# Altium schematic sheet Sheet1.SchDoc
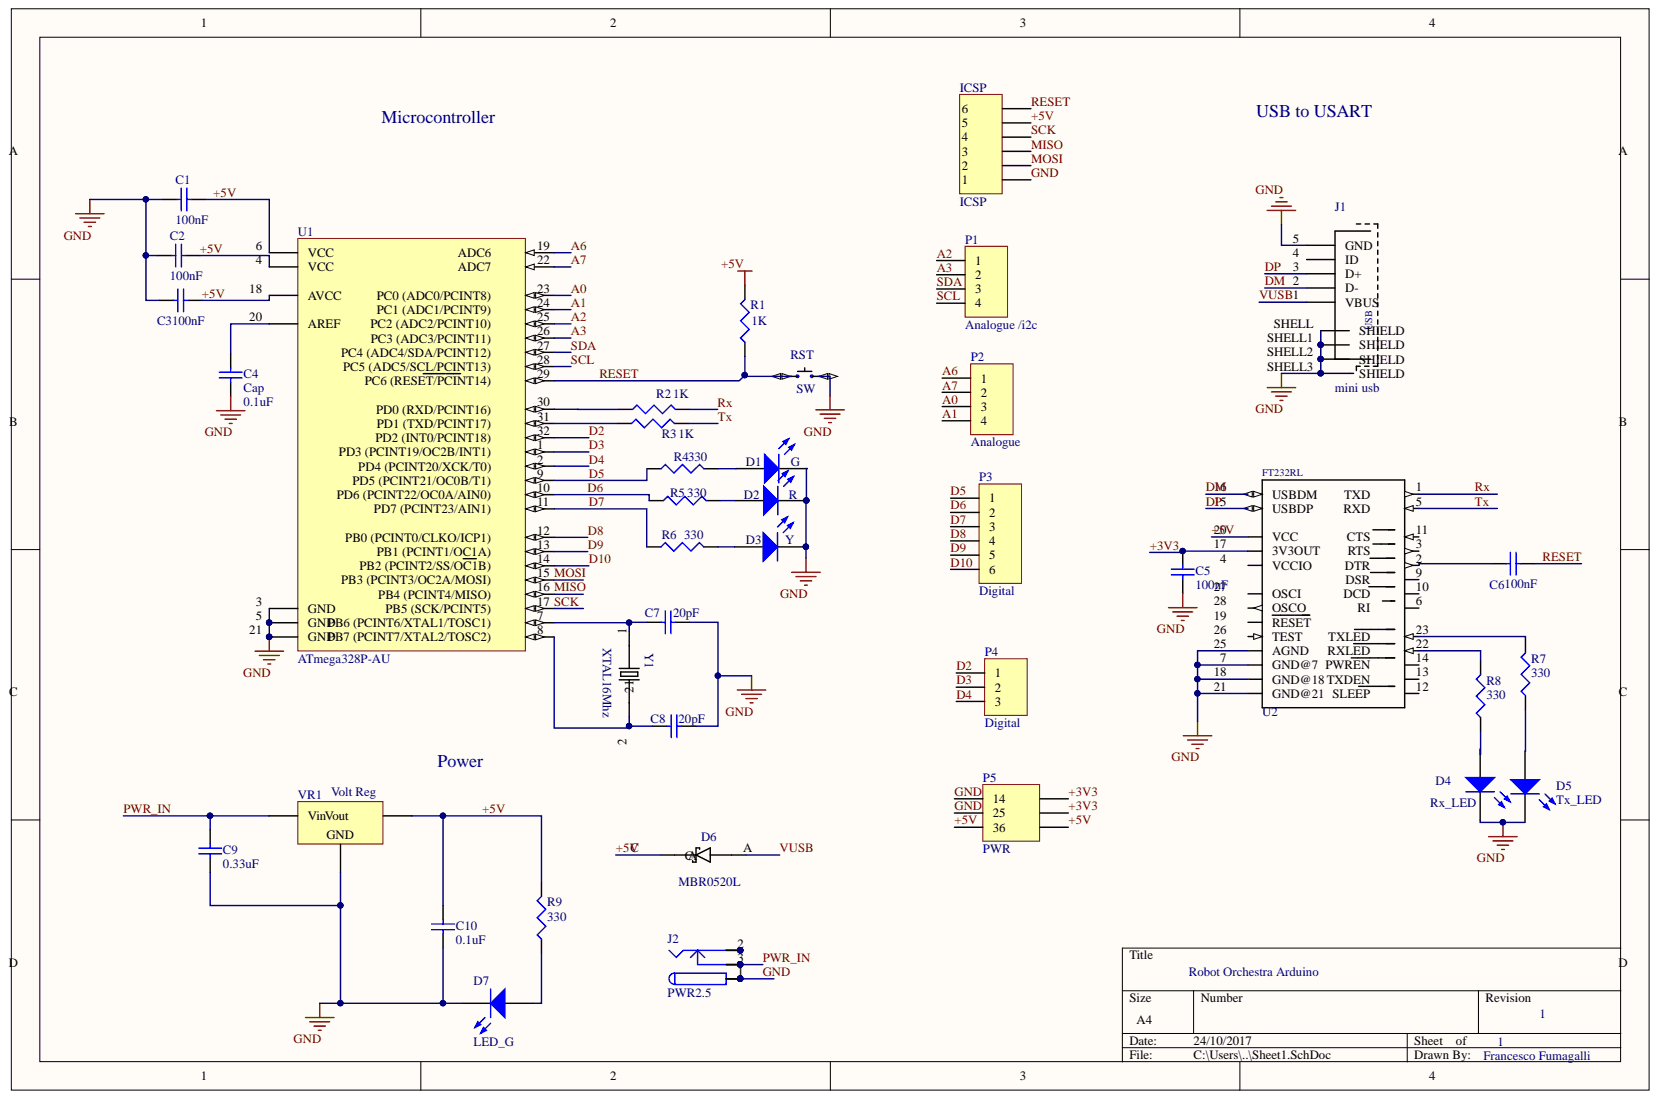
[diagram p0: Sheet1.SchDoc]

## Parts
C1  value 100nF  [CAPC1608X05N]  (hidden comment: Cap)
C2  value 100nF  [CAPC1608X05N]  (hidden comment: Cap)
C3  value 100nF  [CAPC1608X05N]  (hidden comment: Cap)
C4  Cap  value 0.1uF  [CAPC1608X05N]
C5  value 100nF  [CAPC1608X05N]  (hidden comment: Cap)
C6  value 100nF  [CAPC1608X05N]  (hidden comment: Cap)
C7  value 20pF  [CAPC1608X05N]
C8  value 20pF  [CAPC1608X05N]
C9  value 0.33uF  [CAPC1608X05N]  (hidden comment: Cap)
C10  value 0.1uF  [CAPC1608X05N]  (hidden comment: Cap)
D1  G  [CAPC1608X05N]
D2  R  [CAPC1608X05N]
D3  Y  [CAPC1608X05N]
D4  Rx_LED  [CAPC1608X05N]
D5  Tx_LED  [CAPC1608X05N]
D6  MBR0520L  [SOD3716X135NpbMBR0520LT1G(Primary)]
D7  LED_G  [CAPC1608X05N]
ICSP  ICSP  [HDR1X6]
J1  mini usb  [65100516121_65100516121(Primary)]
J2  PWR2.5  [KLD-0202]
P1  Analogue /i2c  [HDR1X4]
P2  Analogue  [HDR1X4]
P3  Digital  [HDR1X6]
P4  Digital  [HDR1X3]
P5  PWR  [HDR2X3_CEN]
R1  value 1K  [CAPC1608X05N]  (hidden comment: Res3)
R2  value 1K  [CAPC1608X05N]
R3  value 1K  [CAPC1608X05N]  (hidden comment: Res3)
R4  value 330  [CAPC1608X05N]  (hidden comment: Res3)
R5  value 330  [CAPC1608X05N]  (hidden comment: Res3)
R6  value 330  [CAPC1608X05N]  (hidden comment: Res3)
R7  value 330  [CAPC1608X05N]  (hidden comment: Res3)
R8  value 330  [CAPC1608X05N]  (hidden comment: Res3)
R9  value 330  [CAPC1608X05N]  (hidden comment: Res3)
RST  SW  [SKHLAAA010]
U1  ATmega328P-AU  [32A_N]
U2  FT232RL  [SSOP28-LD]
VR1  Volt Reg  [SOT230P700X180-4N]
Y1  XTAL16Mhz  [XTAL1170X500X450]

## Nets
+3V3: C5.1 P5.4 P5.5 U2.17(3V3OUT)
+5V: C1.2 C2.2 C3.2 C10.2 D6.C ICSP.5 P5.3(3_6) P5.6 R1.2 R9.2 U1.4(VCC) U1.6(VCC) U1.18(AVCC) U2.20(VCC) VR1.3
A0: P2.3 U1.23
A1: P2.4 U1.24
A2: P1.1 U1.25
A3: P1.2 U1.26
A6: P2.1 U1.19
A7: P2.2 U1.22
D2: P4.1 U1.32
D3: P4.2 U1.1
D4: P4.3 U1.2
D5: P3.1 R4.1 U1.9
D6: P3.2 R5.1 U1.10
D7: P3.3 R6.1 U1.11
D8: P3.4 U1.12
D9: P3.5 U1.13
D10: P3.6 U1.14
DM: J1.2(D-) U2.16(USBDM)
DP: J1.3(D+) U2.15(USBDP)
GND: C1.1 C2.1 C3.1 C4.1 C5.2 C7.2 C8.2 C9.2 C10.1 D1.2 D2.2 D3.2 D4.2 D5.2 D7.2 ICSP.1 J1.5(GND) J1.SHELL J1.SHELL1 J1.SHELL2 J1.SHELL3 J2.1 J2.1A J2.1B P5.1(1_4) P5.2(2_5) RST.2 U1.3(GND) U1.5(GND) U1.21(GND) U2.7(GND@7) U2.18 U2.21(GND@21) U2.25(AGND) VR1.2
MISO: ICSP.3 U1.16
MOSI: ICSP.2 U1.15
PWR_IN: C9.1 J2.3 J2.3A J2.3B VR1.1
RESET: C6.2 ICSP.6 R1.1 RST.1 U1.29
RX: R2.2 U2.1
SCK: ICSP.4 U1.17
SCL: P1.4 U1.28
SDA: P1.3 U1.27
TX: R3.2 U2.5
VUSB: D6.A J1.1(VBUS)
NetC4_2: C4.2 U1.20(AREF)
NetC6_1: C6.1 U2.2
NetC7_1: C7.1 U1.7 Y1.1
NetC8_1: C8.1 U1.8 Y1.2
NetD1_1: D1.1 R4.2
NetD2_1: D2.1 R5.2
NetD3_1: D3.1 R6.2
NetD4_1: D4.1 R8.1
NetD5_1: D5.1 R7.1
NetD7_1: D7.1 R9.1
NetJ2_2: J2.2 J2.2A J2.2B
NetR2_1: R2.1 U1.30
NetR3_1: R3.1 U1.31
NetR7_2: R7.2 U2.23
NetR8_2: R8.2 U2.22

Not connected: J1 4(ID); U2 3 4(VCCIO) 6 9 10 11 12 13 14 19(RESET) 26(TEST) 27(OSCI) 28(OSCO)

## Library data of the parts
C1-C10 = Cap: Capacitor; Component Kind: Standard; LatestRevisionDate: 17-Jul-2002; LatestRevisionNote: Re-released for DXP Platform.; Library Name: Miscellaneous Devices.IntLib; PackageDescription: Radial Cap, Thru-Hole; 2 Leads; 0.3 in Pin Spacing; PackageReference: RAD-0.3; Published: 8-Jun-2000; Signal Integrity: Cap; Simulation: CAP; Publisher: Altium Limited
D1-D5 D7 = LED0: Typical INFRARED GaAs LED; Component Kind: Standard; LatestRevisionDate: 08-Jul-2005; LatestRevisionNote: Mech Layer 1 removed.; Library Name: Miscellaneous Devices.IntLib; PackageDescription: LED; 2 Leads; PackageReference: LED-0; Published: 8-Jun-2000; Simulation: LED0; Publisher: Altium Limited
D6 = MBR0520LT1G: Rectifier, Schottky Power; Plastic Sod-123; 0.5 a @ +90c If; +125; 20v; 1000; MF: ON Semiconductor; Availability: Good; Component Kind: Standard; Library Name: MBR0520LT1G.SchLib; MP: MBR0520LT1G; Package: SOD-123 ON Semiconductor; Price: 0.13 USD
ICSP P3 = Header 6: Header, 6-Pin; Component Kind: Standard; LatestRevisionDate: 17-Jul-2002; LatestRevisionNote: Re-released for DXP Platform.; Library Name: Miscellaneous Connectors.IntLib; Signal Integrity: Connector; Publisher: Altium Limited
J1 = 65100516121: WR-COM Series Right Angle SMT Type B Mini USB Connector Receptacle, 30 V ac, 1A; MF: WÃ¼rth Elektronik; Availability: Good; Component Kind: Standard; Library Name: 65100516121.IntLib; MP: 65100516121; Package: None; Price: 1.10 USD
J2 = PWR2.5: Low Voltage Power Supply Connector; Component Kind: Standard; ComponentLink1Description: Manufacturer Link; ComponentLink1URL: http://domino.kycon.com/internet/Products.html; DatasheetDocument: 09-May-2002; Library Name: Miscellaneous Connectors.IntLib; Published: 17-Dec-2003; Signal Integrity: Connector; Publisher: Altium Limited
P1 P2 = Header 4: Header, 4-Pin; Component Kind: Standard; LatestRevisionDate: 17-Jul-2002; LatestRevisionNote: Re-released for DXP Platform.; Library Name: Miscellaneous Connectors.IntLib; Signal Integrity: Connector; Publisher: Altium Limited
P4 = Header 3: Header, 3-Pin; Component Kind: Standard; LatestRevisionDate: 17-Jul-2002; LatestRevisionNote: Re-released for DXP Platform.; Library Name: Miscellaneous Connectors.IntLib; Signal Integrity: Connector; Publisher: Altium Limited
P5 = Header 3X2A: Header, 3-Pin, Dual row; Component Kind: Standard; LatestRevisionDate: 17-Jul-2002; LatestRevisionNote: Re-released for DXP Platform.; Library Name: Miscellaneous Connectors.IntLib; Signal Integrity: Connector; Publisher: Altium Limited
R1-R9 = Res3: Resistor; Component Kind: Standard; LatestRevisionDate: 29-May-2009; LatestRevisionNote: IPC-7351 Footprint Added.; Library Name: Miscellaneous Devices.IntLib; PackageDescription: Chip Resistor; PackageReference: J1-0603; Published: 8-Jun-2000; Signal Integrity: Res; Simulation: RESISTOR; Publisher: Altium Limited
RST = SKHLAAA010: Single Pole Single Throw Tactile Pushbutton Switch Off Momentaty (On); MF: ALPS; Availability: Good; Component Kind: Standard; Library Name: SKHLAAA010.SchLib; MP: SKHLAAA010; Package: None; Price: 0.35 USD
U1 = ATmega328P-AU: 8-bit AVR Microcontroller, 32KB Flash, 1KB EEPROM, 2KB SRAM, 32-pin TQFP, Industrial Grade (-40°C to 85°C); Code_JEDEC: MS-026-ABA; Component Kind: Standard; ComponentLink1Description: Manufacturer URL; ComponentLink1URL: http://www.atmel.com/; ComponentLink2Description: ATmega48A/48PA/88A/88PA/168A/168PA/328/328P Datasheet; ComponentLink2URL: http://www.atmel.com/dyn/resources/prod_documents/doc8271.pdf; ComponentLink3Description: ATmega48A/48PA/88A/88PA/168A/168PA/328/328P Summary; ComponentLink3URL: http://www.atmel.com/dyn/resources/prod_documents/8271S.pdf; DatasheetVersion: revD, May-2011; Library Name: Atmel Microcontroller megaAVR.IntLib; PackageDescription: 32-Lead, 7x7x1.0 mm Body, 0.8mm Lead Pitch, Thin Profile Plastic Quad Flat Package (TQFP); PackageReference: 32A; PackageVersion: revC, Oct-2010
U2 = FT232RL: USB-to-UART 1-CH 256Byte FIFO 5V 28-Pin SSOP; MF: FTDI; Availability: Good; Component Kind: Standard; Library Name: FT232RL.IntLib; MP: FT232RL; Package: None; Price: 5.18 USD
VR1 = Volt Reg: Voltage Regulator; Component Kind: Standard; LatestRevisionDate: 29-May-2009; LatestRevisionNote: IPC-7351 Footprint Added.; Library Name: Miscellaneous Devices.IntLib; PackageDescription: 3-Pin Transistor Outline Package 2.54 mm Pitch, 15.1 mm Lead Span; PackageReference: D2PAK; Published: 24-Mar-1999; Publisher: Altium Limited
Y1 = FOXSDLF/040: Crystal 4MHz ?30ppm (Tol) ?50ppm (Stability) 20pF FUND 200Ohm 2-Pin HC-49/SDLF SMD T/R; MF: Fox Electronics; Component Kind: Standard; Digi-Key_Part_Number: 631-1005-1-ND; Digi-Key_Purchase_URL: https://www.digikey.co.uk/product-detail/en/fox-electronics/FOXSDLF-040/631-1005-1-ND/1024710?WT.z_cid=ref_snapeda; Library Name: FOXSDLF_040.IntLib; MP: FOXSDLF/040; Package: HC49SD Fox Electronics
